# Altium schematic sheet power_delivery.SchDoc(power_delivery)
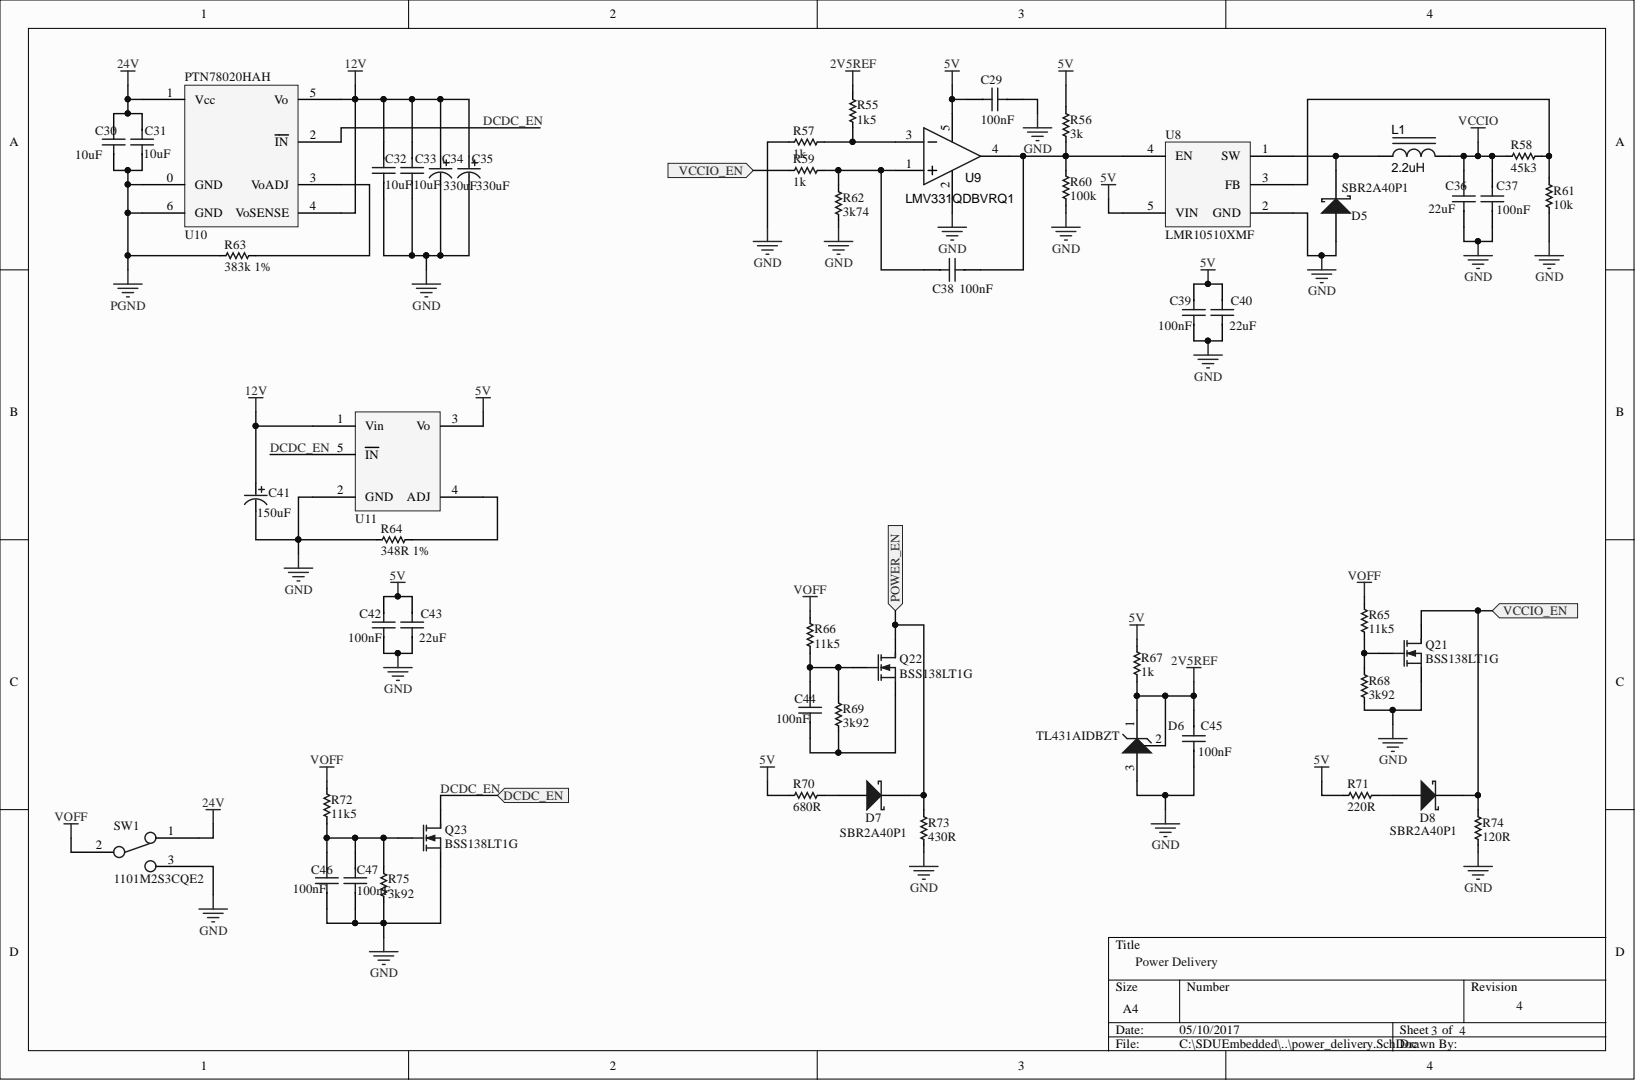
[diagram p0: power_delivery.SchDoc]

## Parts
C29  value 100nF  [CAP-0805]  (hidden comment: Capacitor)
C30  value 10uF  [CAP-0805]  (hidden comment: Capacitor)
C31  value 10uF  [CAP-0805]  (hidden comment: Capacitor)
C32  value 10uF  [CAP-0805]  (hidden comment: Capacitor)
C33  value 10uF  [CAP-0805]  (hidden comment: Capacitor)
C34  value 330uF  [CAP-H13]
C35  value 330uF  [CAP-H13]
C36  value 22uF  [CAP-0805]  (hidden comment: Capacitor)
C37  value 100nF  [CAP-0805]  (hidden comment: Capacitor)
C38  value 100nF  [CAP-0805]  (hidden comment: Capacitor)
C39  value 100nF  [CAP-0805]  (hidden comment: Capacitor)
C40  value 22uF  [CAP-0805]  (hidden comment: Capacitor)
C41  value 150uF  [CAP-F12]  (hidden comment: 20SVP150M)
C42  value 100nF  [CAP-0805]  (hidden comment: Capacitor)
C43  value 22uF  [CAP-0805]  (hidden comment: Capacitor)
C44  value 100nF  [CAP-0805]  (hidden comment: Capacitor)
C45  value 100nF  [CAP-0805]  (hidden comment: Capacitor)
C46  value 100nF  [CAP-0805]  (hidden comment: Capacitor)
C47  value 100nF  [CAP-0805]  (hidden comment: Capacitor)
D5  SBR2A40P1  [POWERDI-123]
D6  TL431AIDBZT  [SOT-23B]
D7  SBR2A40P1  [POWERDI-123]
D8  SBR2A40P1  [POWERDI-123]
L1  2.2uH  [SRN5020-2R2Y_FP]
Q21  BSS138LT1G  [SOT-23B]
Q22  BSS138LT1G  [SOT-23B]
Q23  BSS138LT1G  [SOT-23B]
R55  value 1k5  [RES-0805]  (hidden comment: Resistor)
R56  value 3k  [RES-0805]  (hidden comment: Resistor)
R57  value 1k  [RES-0805]  (hidden comment: Resistor)
R58  value 45k3  [RES-0805]  (hidden comment: Resistor)
R59  value 1k  [RES-0805]  (hidden comment: Resistor)
R60  value 100k  [RES-0805]  (hidden comment: Resistor)
R61  value 10k  [RES-0805]  (hidden comment: Resistor)
R62  value 3k74  [RES-0805]  (hidden comment: Resistor)
R63  value 383k 1%  [RES-0805]  (hidden comment: Resistor)
R64  value 348R 1%  [RES-0805]  (hidden comment: Resistor)
R65  value 11k5  [RES-0805]  (hidden comment: Resistor)
R66  value 11k5  [RES-0805]  (hidden comment: Resistor)
R67  value 1k  [RES-0805]  (hidden comment: Resistor)
R68  value 3k92  [RES-0805]  (hidden comment: Resistor)
R69  value 3k92  [RES-0805]  (hidden comment: Resistor)
R70  value 680R  [RES-0805]  (hidden comment: Resistor)
R71  value 220R  [RES-0805]  (hidden comment: Resistor)
R72  value 11k5  [RES-0805]  (hidden comment: Resistor)
R73  value 430R  [RES-0805]  (hidden comment: Resistor)
R74  value 120R  [RES-0805]  (hidden comment: Resistor)
R75  value 3k92  [RES-0805]  (hidden comment: Resistor)
SW1  1101M2S3CQE2  [1101M2S3CQE2_FP]
U8  LMR10510XMF  [SOT23-5]
U9  LMV331QDBVRQ1  [SOT23-5]
U10  PTN78020HAH  [PTN78020]
U11  PTH08080WAH  [PTH08080W_FP]  (value not drawn)

## Ports
DCDC_EN, POWER_EN, VCCIO_EN

## Nets
2V5REF: C45.2 D6.1 D6.2 R55.2 R67.2
5V: C29.1 C39.2 C40.2 C42.2 C43.2 R56.2 R67.1 R70.1 R71.1 U8.5(VIN) U9.5 U11.3(Vo)
12V: C32.2 C33.2 C34.1 C35.1 C41.1 U10.4(VoSENSE) U10.5(Vo) U11.1(Vin)
24V: C30.2 C31.2 SW1.1 U10.1(Vcc)
GND: C29.2 C32.1 C33.1 C34.2 C35.2 C36.1 C37.1 C39.1 C40.1 C41.2 C42.1 C43.1 C45.1 C46.1 C47.1 D5.1 D6.3 Q21.2 Q23.2 R57.1 R60.2 R61.1 R62.1 R64.1 R68.1 R73.1 R74.1 R75.1 SW1.3 U8.2(GND) U9.2 U11.2(GND)
PGND: C30.1 C31.1 R63.1 U10.0(GND) U10.6(GND)
VCCIO: C36.2 C37.2 L1.2 R58.1
VOFF: R65.1 R66.1 R72.1 SW1.2
DCDC_EN: Q23.3 U10.2(IN) U11.5(IN) ; port DCDC_EN
POWER_EN: D7.2 Q22.3 R73.2 ; port POWER_EN
VCCIO_EN: D8.2 Q21.3 R59.1 R74.2 ; port VCCIO_EN
NetC38_1: C38.1 R59.2 R62.2 U9.1
NetC38_2: C38.2 R56.1 R60.1 U8.4(EN) U9.4
NetC44_1: C44.1 Q22.2 R69.1
NetC44_2: C44.2 Q22.1 R66.2 R69.2
NetC46_2: C46.2 C47.2 Q23.1 R72.2 R75.2
NetD5_2: D5.2 L1.1 U8.1(SW)
NetD7_1: D7.1 R70.2
NetD8_1: D8.1 R71.2
NetQ21_1: Q21.1 R65.2 R68.2
NetR55_1: R55.1 R57.2 U9.3
NetR58_2: R58.2 R61.2 U8.3(FB)
NetR63_2: R63.2 U10.3(VoADJ)
NetR64_2: R64.2 U11.4(ADJ)

## Library data of the parts
C34 C35 C41 = Electrolytic Capacitor: Component Kind: Standard; Library Name: SDUEmbedded.SchLib
D5 D7 D8 = SBR2A40P1: Schottky Rectifier; Note: Terminal 2 is the TAB and is electrically connected to the cathode; Published: 8-Jun-2000; PackageVersion: Feb-1998; PackageReference: TO-220AC; PackageDescription: TO, Thru-Hole, Vertical, Heatsink Mounted; 3 In-Line Leads (1 Absent); Pitch 2.54 mm; LatestRevisionDate: 17-Jul-2002; DatasheetVersion: Dec-1997; ComponentLink2URL: http://www.irf.com/product-info/datasheets/data/18tq.pdf; ComponentLink2Description: Datasheet; ComponentLink1URL: http://www.irf.com/; ComponentLink1Description: Manufacturer Link; Component Kind: Standard; Publisher: Altium Limited; Library Name: SDUEmbedded.SchLib
D6 = TL431AIDBZT: Variable voltage  reference; Note: Pin 2 is not connected and not drawn.; Published: 21-Mar-2003; PackageVersion: Sep-2001; PackageReference: SOT-23; PackageDescription: 3-Pin SOT-23 Package 0.95 mm Pitch, 2.5 mm Lead Span; LatestRevisionNote: IPC-7351 Footprint Added.; LatestRevisionDate: 29-May-2009; DatasheetVersion: Oct-1999; ComponentLink2URL: http://www.infineon.com/upload/Document/cmc_upload/documents/012/5331/bas16series.pdf; ComponentLink2Description: Datasheet; ComponentLink1URL: http://www.infineon.com/; ComponentLink1Description: Manufacturer Link; Component Kind: Standard; Publisher: Altium Limited; Library Name: SDUEmbedded.SchLib
L1 = SRN5020-2R2Y: Manufacturer Part Number 1: DR73-100-R; Manufacturer 1: Cooper Bussmann; Tolerance: ±20%; SCDType: spec; Ratings: 2.1 A; Material: ferrite shielded; Value: 2.2uH; Supplier Part Number 1: 513-1129-1-ND; Supplier 1: Digi-Key; Package / Case: 5.0x5.0x4.5mm; Description: SRN5020-2R2Y; ComponentLink1URL: http://www.cooperindustries.com/content/dam/public/bussmann/Electronics/Resources/Data%20Sheets/Bus_Elx_DS_4315_DR_Series.pdf; ComponentLink1Description: http://www.cooperindustries.com/content/dam/public/bussmann/Electronics/Resources/Data%20Sheets/Bus_Elx_DS_4315_DR_Series.pdf; Component Kind: Standard; Library Name: swarmbot_schematic_library.SchLib
Q21-Q23 = BSS138LT1G: N-Channel MOSFET; Published: 8-Jun-2000; PackageVersion: 1995/1996; PackageReference: E3; PackageDescription: TO, Flat Index; 3 In-Line, Axial Leads; Body Dia. 4.6mm; Lead Dia. 0.5 mm (max); LatestRevisionDate: 17-Jul-2002; Component Kind: Standard; Publisher: Altium Limited; Library Name: SDUEmbedded.SchLib
U9 = LMV331QDBVRQ1: IC SMD AMP+COMPAR Combo SOIC-8; Manufacturer Part Number 1: TLV2702ID; Manufacturer 1: Texas Instruments; Voltage - Supply: 2.7 V ~ 16 V, ±1.35 V ~ 8 V; SCDType: undef; Supplier Part Number 1: 296-12503-5-ND; Supplier 1: Digi-Key; Package / Case: 8-SOIC (0.154", 3.90mm Width); Component Kind: Standard; Library Name: SDUEmbedded.SchLib
C29-C33 C36-C40 C42-C47 = Capacitor: Description: Capacitor; Component Kind: Standard; Library Name: SDUEmbedded.SchLib
R55-R75 = Resistor: Description: Resistor; Component Kind: Standard; Library Name: SDUEmbedded.SchLib
SW1 = 1101M2S3CQE2: Component Kind: Standard; Library Name: SDUEmbedded.SchLib
U8 = LMR10510XMF: Component Kind: Standard; Library Name: SDUEmbedded.SchLib
U10 = PTN78020HAH: Supplier Part Number 1: 6618171; Supplier 1: RSComponents; Component Kind: Standard; Library Name: SDUEmbedded.SchLib
U11 = PTH08080WAH: Supplier Part Number 1: 661-7948P; Supplier 1: RSComponents; Component Kind: Standard; Library Name: SDUEmbedded.SchLib
